# Altium schematic sheet Connectors.SchDoc(Connectors)
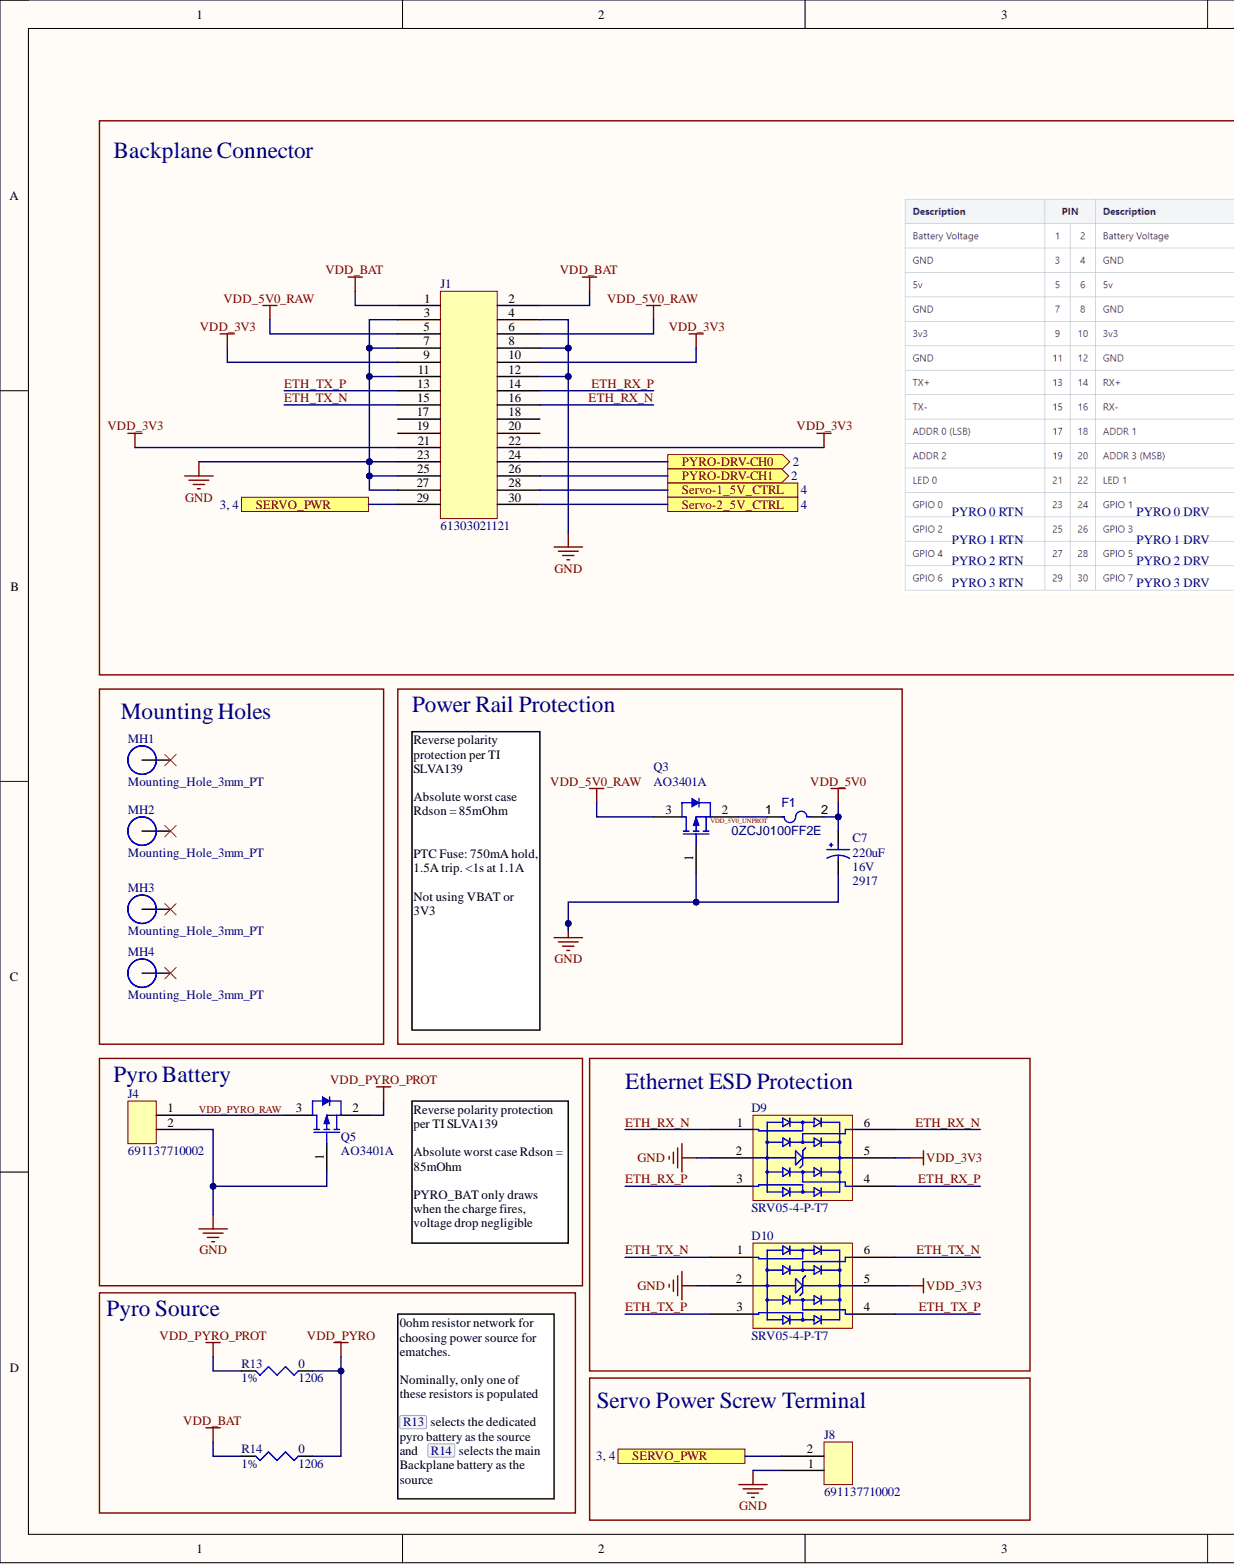
[diagram p0: Connectors.SchDoc, left]
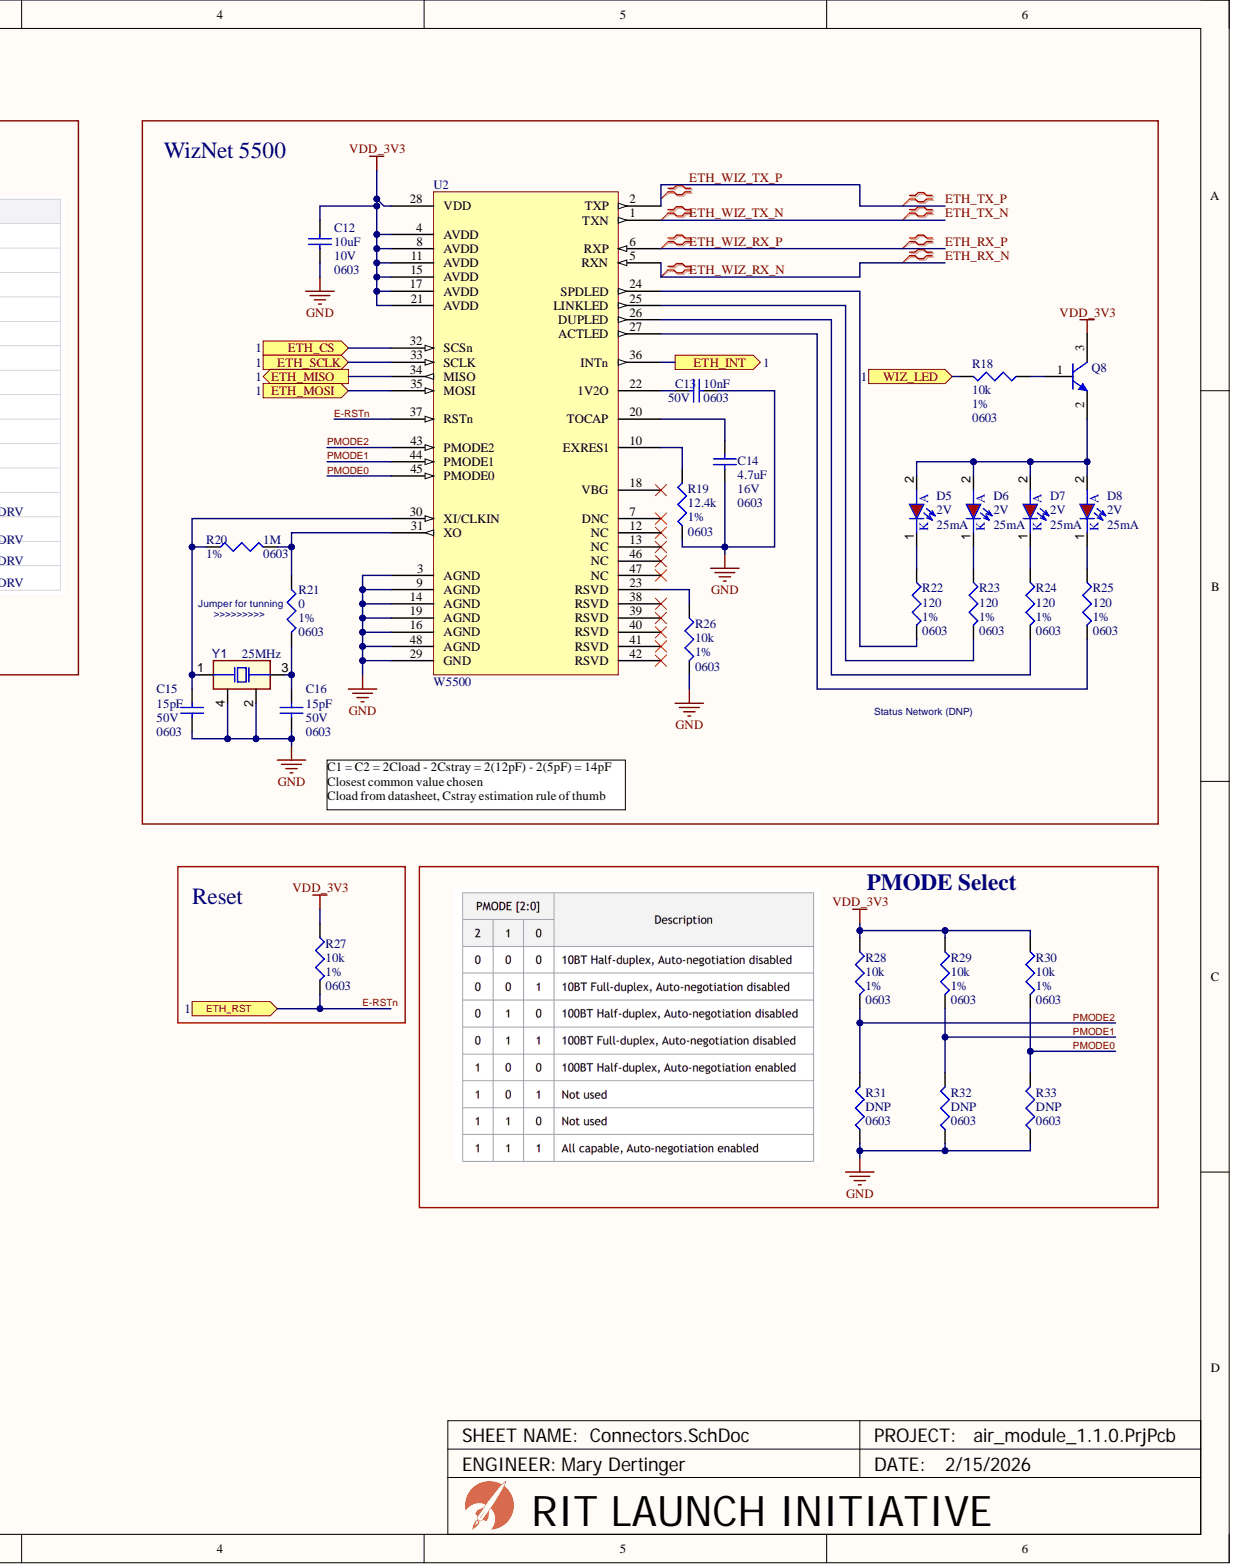
[diagram p1: Connectors.SchDoc, right]

## Parts
C7  Capacitance: 220uF  Case/Package: 2917  Voltage: 16V  (hidden comment: TAJE227K016RNJ)
C12  Capacitance: 10uF  Case/Package: 0603  Voltage: 10V  (hidden comment: CL10A106KP8NNNC)
C13  Capacitance: 10nF  Case/Package: 0603  Voltage: 50V  (hidden comment: 0603B103K500NT)
C14  Capacitance: 4.7uF  Case Code (Imperial): 0603  Voltage: 16V  (hidden comment: CL10A475KO8NNNC)
C15  Capacitance: 15pF  Case/Package: 0603  Voltage: 50V  (hidden comment: CL10C150JB8NNNC)
C16  Capacitance: 15pF  Case/Package: 0603  Voltage: 50V  (hidden comment: CL10C150JB8NNNC)
D5  Forward Voltage: 2V  Max Current: 25mA  (hidden comment: SPK)
D6  Forward Voltage: 2V  Max Current: 25mA  (hidden comment: LNK)
D7  Forward Voltage: 2V  Max Current: 25mA  (hidden comment: DUP)
D8  Forward Voltage: 2V  Max Current: 25mA  (hidden comment: ACT)
D9  SRV05-4-P-T7
D10  SRV05-4-P-T7
F1  0ZCJ0100FF2E
J1  61303021121
J4  691137710002
J8  691137710002  Termination: Screw
MH1  Mounting_Hole_3mm_PT
MH2  Mounting_Hole_3mm_PT
MH3  Mounting_Hole_3mm_PT
MH4  Mounting_Hole_3mm_PT
Q3  AO3401A
Q5  AO3401A
Q8  DTC614TUT106  (value not drawn)
R13  Case/Package: 1206  Tolerance: 1%  (hidden comment: 1206W4F0000T5E)
R14  Case/Package: 1206  Tolerance: 1%  (hidden comment: 1206W4F0000T5E)
R18  Case/Package: 0603  Resistance: 10k  Tolerance: 1%  (hidden comment: 0603WAF1002T5E)
R19  Case/Package: 0603  Resistance: 12.4k  Tolerance: 1%  (hidden comment: 0603WAF1242T5E)
R20  Case/Package: 0603  Resistance: 1M  Tolerance: 1%  (hidden comment: 0603WAF1004T5E)
R21  Case/Package: 0603  Tolerance: 1%  (hidden comment: 0603WAF0000T5E)
R22  Case/Package: 0603  Tolerance: 1%  (hidden comment: 0603WAF1200T5E)
R23  Case/Package: 0603  Tolerance: 1%  (hidden comment: 0603WAF1200T5E)
R24  Case/Package: 0603  Tolerance: 1%  (hidden comment: 0603WAF1200T5E)
R25  Case/Package: 0603  Tolerance: 1%  (hidden comment: 0603WAF1200T5E)
R26  Case/Package: 0603  Resistance: 10k  Tolerance: 1%  (hidden comment: 0603WAF1002T5E)
R27  Case/Package: 0603  Resistance: 10k  Tolerance: 1%  (hidden comment: 0603WAF1002T5E)
R28  Case/Package: 0603  Resistance: 10k  Tolerance: 1%  (hidden comment: 0603WAF1002T5E)
R29  Case/Package: 0603  Resistance: 10k  Tolerance: 1%  (hidden comment: 0603WAF1002T5E)
R30  Case/Package: 0603  Resistance: 10k  Tolerance: 1%  (hidden comment: 0603WAF1002T5E)
R31  Case/Package: 0603  Resistance: DNP  (hidden comment: DNP RES 0603)
R32  Case/Package: 0603  Resistance: DNP  (hidden comment: DNP RES 0603)
R33  Case/Package: 0603  Resistance: DNP  (hidden comment: DNP RES 0603)
U2  W5500
Y1  Frequency: 25MHz  (hidden comment: X322525MOB4SI)

## Ports
ETH_CS, ETH_INT, ETH_MISO, ETH_MOSI, ETH_RST, ETH_SCLK, PYRO-DRV-CH0, PYRO-DRV-CH1, Servo-1_5V_CTRL, Servo-2_5V_CTRL, SERVO_PWR, WIZ_LED

## Nets
GND: C7.2 C12.1 C13.2 C14.2 C15.1 C16.1 D9.2 D10.2 J1.3 J1.4 J1.7 J1.8 J1.11 J1.12 J1.23 J1.25 J1.27 J4.2 J8.1 Q3.1 Q5.1 R19.1 R26.2 R31.1 R32.1 R33.1 U2.3(AGND) U2.9(AGND) U2.14(AGND) U2.16(AGND) U2.19(AGND) U2.29(GND) U2.48(AGND) Y1.2 Y1.4
VDD_3V3: C12.2 D9.5 D10.5 J1.9 J1.10 J1.21 J1.22 Q8.3 R27.1 R28.1 R29.1 R30.1 U2.4(AVDD) U2.8(AVDD) U2.11(AVDD) U2.15(AVDD) U2.17(AVDD) U2.21(AVDD) U2.28(VDD)
VDD_5V0: C7.1 F1.2
VDD_5V0_RAW: J1.5 J1.6 Q3.3
VDD_BAT: J1.1 J1.2 R14.2
VDD_PYRO: R13.1 R14.1
VDD_PYRO_PROT: Q5.2 R13.2
E-RSTn: R27.2 U2.37(RSTn) ; port ETH_RST
ETH_CS: U2.32(SCSn) ; port ETH_CS
ETH_INT: U2.36(INTn) ; port ETH_INT
ETH_MISO: U2.34(MISO) ; port ETH_MISO
ETH_MOSI: U2.35(MOSI) ; port ETH_MOSI
ETH_RX_N: D9.1 D9.6 J1.16 U2.5(RXN)
ETH_RX_P: D9.3 D9.4 J1.14 U2.6(RXP)
ETH_SCLK: U2.33(SCLK) ; port ETH_SCLK
ETH_TX_N: D10.1 D10.6 J1.15 U2.1(TXN)
ETH_TX_P: D10.3 D10.4 J1.13 U2.2(TXP)
PMODE0: R30.2 R33.2 U2.45(PMODE0)
PMODE1: R29.2 R32.2 U2.44(PMODE1)
PMODE2: R28.2 R31.2 U2.43(PMODE2)
PYRO-DRV-CH0: J1.24 ; port PYRO-DRV-CH0
PYRO-DRV-CH1: J1.26 ; port PYRO-DRV-CH1
Servo-1_5V_CTRL: J1.28 ; port Servo-1_5V_CTRL
Servo-2_5V_CTRL: J1.30 ; port Servo-2_5V_CTRL
SERVO_PWR: J1.29 J8.2 ; port SERVO_PWR
VDD_5V0_UNPROT: F1.1 Q3.2
VDD_PYRO_RAW: J4.1 Q5.3
WIZ_LED: R18.2 ; port WIZ_LED
NetC13_1: C13.1 U2.22(1V2O)
NetC14_1: C14.1 U2.20(TOCAP)
NetC15_2: C15.2 R20.1 U2.30(XI/CLKIN) Y1.1
NetC16_2: C16.2 R21.2 Y1.3
NetD5_1: D5.1 R22.1
NetD5_2: D5.2 D6.2 D7.2 D8.2 Q8.2
NetD6_1: D6.1 R23.1
NetD7_1: D7.1 R24.1
NetD8_1: D8.1 R25.1
NetQ8_1: Q8.1 R18.1
NetR19_2: R19.2 U2.10(EXRES1)
NetR20_2: R20.2 R21.1 U2.31(XO)
NetR22_2: R22.2 U2.24(SPDLED)
NetR23_2: R23.2 U2.25(LINKLED)
NetR24_2: R24.2 U2.26(DUPLED)
NetR25_2: R25.2 U2.27(ACTLED)
NetR26_1: R26.1 U2.23(RSVD)

Not connected: J1 17 18 19 20; MH1 1; MH2 1; MH3 1; MH4 1; U2 7(DNC) 12(NC) 13(NC) 18(VBG) 38(RSVD) 39(RSVD) 40(RSVD) 41(RSVD) 42(RSVD) 46(NC) 47(NC)

## Library data of the parts
C7 = CMP-001-0314-2: CAP TANT 220UF 10% 16V 2917; Dielectric Material: Tantalum; ESR (Equivalent Series Resistance): 500mR; Leakage Current: 35.2uA; Polarity: Polar; Voltage Rating (DC): 16.3V; Component Kind: Standard; Construction: Flat; Depth: 4.3mm; Dissipation Factor: 10%; Features: General Purpose; Height: 4.1mm; Height - Seated (Max): 0.169inch; JLCPCB Part #: C7231; Lead Free: Lead Free; Length: 7.3mm; Max Operating Temperature: 125°C; Min Operating Temperature: -55°C; Mounting Technology: Surface Mount; Package Quantity: 400; Packaging: Tape and Reel; Pins: 2; Radiation Hardening: No; Reach SVHC Compliant: Yes; RoHS Compliant: Yes; Series: TAJ; Termination: SMD/SMT; Tolerance: 10%; Voltage Rating: 16V; Width: 4.3mm; Library Name: RIT Launch Initiative
C12 = CMP-001-0266-1: CAP CER 10UF 10V X5R 0603; Material: Ceramic; Tolerance: 10%; Component Kind: Standard; Construction: Flat; Contact Plating: Tin; Depth: 0.8mm; Dielectric: X5R; Height: 0.8mm; JLCPCB Part #: C19702; Lead Free: Lead Free; Length: 1.6mm; Max Operating Temperature: 85°C; Min Operating Temperature: -55°C; Mounting Technology: Surface Mount; Package Quantity: 1; Packaging: Tape and Reel; Radiation Hardening: No; RoHS Compliant: Yes; Series: CL; Thickness: 0.035inch; Width: 0.031inch; Library Name: RIT Launch Initiative
C13 = CMP-001-0273-1: CAP CER 10000PF 50V X7R 0603; Component Kind: Standard; JLCPCB Part #: C57112; Library Name: RIT Launch Initiative
C14 = CMP-001-0241-2: CAP CER 4.7UF 16V X5R 0603; Material: Ceramic; Tolerance: 10%; Case Code (Metric): 1608; Case/Package: 0603; Component Kind: Standard; Construction: Flat; Contact Plating: Tin; Depth: 0.8mm; Dielectric: X5R; Height: 0.8mm; JLCPCB Part #: C19666; Length: 1.6mm; Max Operating Temperature: 85°C; Min Operating Temperature: -55°C; Mount: Surface Mount; Package Quantity: 1; Packaging: Tape and Reel; Radiation Hardening: No; RoHS Compliant: Yes; Series: CL; Thickness: 0.035inch; Voltage Rating: 16V; Width: 0.031inch; Library Name: RIT Launch Initiative
C15 C16 = CMP-001-0309-1: CAP CER 15PF 50V C0G 0603; Tolerance: 5%; Component Kind: Standard; Dielectric: C0G; JLCPCB Part #: C1644; Lead Free: Lead Free; Library Name: RIT Launch Initiative
D5-D8 = CMP-001-0246-3: LED RED CLEAR 0805 SMD; Component Kind: Standard; JLCPCB Part #: C84256; Library Name: RIT Launch Initiative
D9 D10 = CMP-001-0239-5: SRV05 Series 4 Ch 3.5 pF 15 Vclamp Steering Diode/TVS Array Combo - SOT-23-6; Capacitance: 3.5pF; Clamping Voltage: 15V; Direction: Unidirectional; Leakage Current: 5uA; Number of Channels: 4; Number of Elements: 1; Peak Pulse Current: 5A; Peak Pulse Power: 500W; Reverse Breakdown Voltage: 6V; Reverse Standoff Voltage: 5V; Test Current: 1mA; Case/Package: SOT-23; Clamping Voltage-Max: 15V; Component Kind: Standard; Depth: 1.75mm; JLCPCB Part #: C85364; Lead Free: Lead Free; Length: 3.05mm; Max Operating Temperature: 150°C; Min Operating Temperature: -55°C; Mount: Surface Mount; Number of Pins: 6; Packaging: Tape and Reel; Polarity: Unidirectional; Radiation Hardening: No; RoHS Compliant: Yes; Working Voltage: 5V; Library Name: RIT Launch Initiative
F1 = CMP-001-0338-1: PTC RESET FUSE 6V 1A 1206; Max Current Rating: 100A; Time to Trip: 300ms; Trip Current: 1.8A; Case Code (Imperial): 1206; Case Code (Metric): 3216; Case/Package: 1206; Component Kind: Standard; Hold Current: 1A; Lead Free: Lead Free; Max Operating Temperature: 85°C; Min Operating Temperature: -40°C; Mounting Technology: Surface Mount; Package Quantity: 3000; Packaging: Cut Tape; Ratings: AEC-Q200; RoHS Compliant: Yes; Series: 0ZCJ; Library Name: RIT Launch Initiative
J1 = CMP-001-0328-2: CONN HEADER VERT 30POS 2.54MM; Gender: Male; Number of Contacts: 30; Pitch: 0.1inch; Voltage Rating: 250V; Color: Black; Component Kind: Standard; Connector Type: Header; Contact Finish - Mating: GOLD; Contact Material: Copper Alloy,Copper; Contact Mating Length: 0.236inch; Contact Plating: Gold; Contact Shape: Square; Contact Type: Male Pin; Fastening Type: Pull; Flammability Rating: UL94 V-0; Insulation Color: Black; Insulation Material: Nylon; JLCPCB Part #: C56496; Max Operating Temperature: 105°C; Min Operating Temperature: -40°C; Mounting Technology: Through Hole; Number of Positions: 30; Number of Rows: 2; Packaging: Bulk; RoHS Compliant: Yes; Row Spacing: 0.1inch; Series: WR-PHD; Termination: Solder; Library Name: RIT Launch Initiative
J4 J8 = CMP-001-0301-1: TERM BLK 2POS SIDE ENTRY 5MM PCB; Current: 16A; Number of Levels: 1; Number of Positions Per Level: 2; Plating: Copper Alloy; Voltage: 300V; Wire Gauge (Max): 30AWG; Wire Gauge (Min): 12AWG; Color: Green; Component Kind: Standard; Housing Material: PC; Max Operating Temperature: 120°C; Min Operating Temperature: -30°C; Mounting Technology: Through Hole; Packaging: Bulk; RoHS Compliant: Yes; Library Name: RIT Launch Initiative
  J4: Termination: Screw
MH1-MH4 = CMP-001-0289-1: 3mm Screw, 6.5mm Head, Plated Mounting Hole; Component Kind: Standard; Library Name: RIT Launch Initiative
Q3 Q5 = CMP-001-0310-2: MOSFET P-CH 30V 4A SOT23-3L; Input Capacitance: 1.2nF; Max Power Dissipation: 1.4W; Number of Elements: 1; Rds On Max: 44mR; Case/Package: TO-236-3; Component Kind: Standard; Continuous Drain Current (ID): 4A; Drain to Source Voltage (Vdss): 30V; JLCPCB Part #: C15127; Lead Free: Lead Free; Max Operating Temperature: 150°C; Min Operating Temperature: -55°C; Mounting Technology: Surface Mount; Packaging: Cut Tape; Pins: 3; Power Dissipation: 1.4W; Radiation Hardening: No; RoHS Compliant: Yes; Series: -; Library Name: RIT Launch Initiative
Q8 = CMP-009-00209-5: No Description Available; Mfr_Name: ROHM Semiconductor; Case/Package: SOT-323; Component Kind: Standard; Design Item ID: DTC614TUT106; JLCPCB Part #: C79963; Max Operating Temperature: 150°C; Pins: 3; RoHS Compliant: Yes; Source: DTC614TUT106.SchLib; Library Name: RIT Launch Initiative
R13 R14 = CMP-001-0344-2: RES 0 OHM 1% 1/4W 1206; Resistance: 0; Component Kind: Standard; JLCPCB Part #: C17888; RoHS Compliant: Yes; Library Name: RIT Launch Initiative
R18 R26-R30 = CMP-001-0268-1: RES 10K OHM 1% 1/10W 0603; Component Kind: Standard; JLCPCB Part #: C25804; RoHS Compliant: Yes; Library Name: RIT Launch Initiative
R19 = CMP-009-00183-2: RES SMD 12.4K OHM 1% 1/10W 0603; Component Kind: Standard; JLCPCB Part #: C22865; Library Name: RIT Launch Initiative
R20 = CMP-009-00182-1: 1MΩ 0603 Chip Resistor 100mW Thick Film Resistors 75V ±100ppm/℃; Component Kind: Standard; JLCPCB Part #: C22935; Library Name: RIT Launch Initiative
R21 = CMP-001-0270-1: RES SMD 0 OHM 1% 1/10W 0603; Resistance: 0; Component Kind: Standard; JLCPCB Part #: C21189; RoHS Compliant: Yes; Library Name: RIT Launch Initiative
R22-R25 = CMP-001-0272-1: RES 120 OHM 1% 1/10W 0603; Resistance: 120; Component Kind: Standard; JLCPCB Part #: C22787; Library Name: RIT Launch Initiative
R31-R33 = CMP-001-0269-1: Do Not Populate 0603 Resistor; Component Kind: Standard; Tolerance: DNP; Library Name: RIT Launch Initiative
U2 = CMP-001-0263-2: IC CTLR 3-1 ETH TCP/IP 48LQFP; Interface: SPI; Max Supply Current: 132mA; Max Supply Voltage: 3.63V; Min Supply Voltage: 2.97V; Number of Functions: 3; Number of Transceivers: 1; Case/Package: LQFP; Component Kind: Standard; JLCPCB Part #: C32843; Lead Free: Lead Free; Max Operating Temperature: 85°C; Min Operating Temperature: -40°C; Number of Pins: 48; Operating Supply Current: 132mA; Package Quantity: 250; Packaging: Strip; RoHS Compliant: Yes; Series: -; Supply Voltage-Nom: 3.63V; Library Name: RIT Launch Initiative
Y1 = CMP-001-0274-1: 25MHz SMD Crystal Resonator 12pF ±10ppm ±30ppm -40℃~+85℃ SMD3225-4P  Crystals ROHS; ESR: 40; Case/Package: SMD/SMT; Component Kind: Standard; JLCPCB Part #: C9006; Load Capacitance: 12pF; Max Operating Temperature: 85°C; Min Operating Temperature: -45°C; Pins: 4; RoHS Compliant: Yes; Library Name: RIT Launch Initiative
